# Altium schematic sheet Sheet1.SchDoc
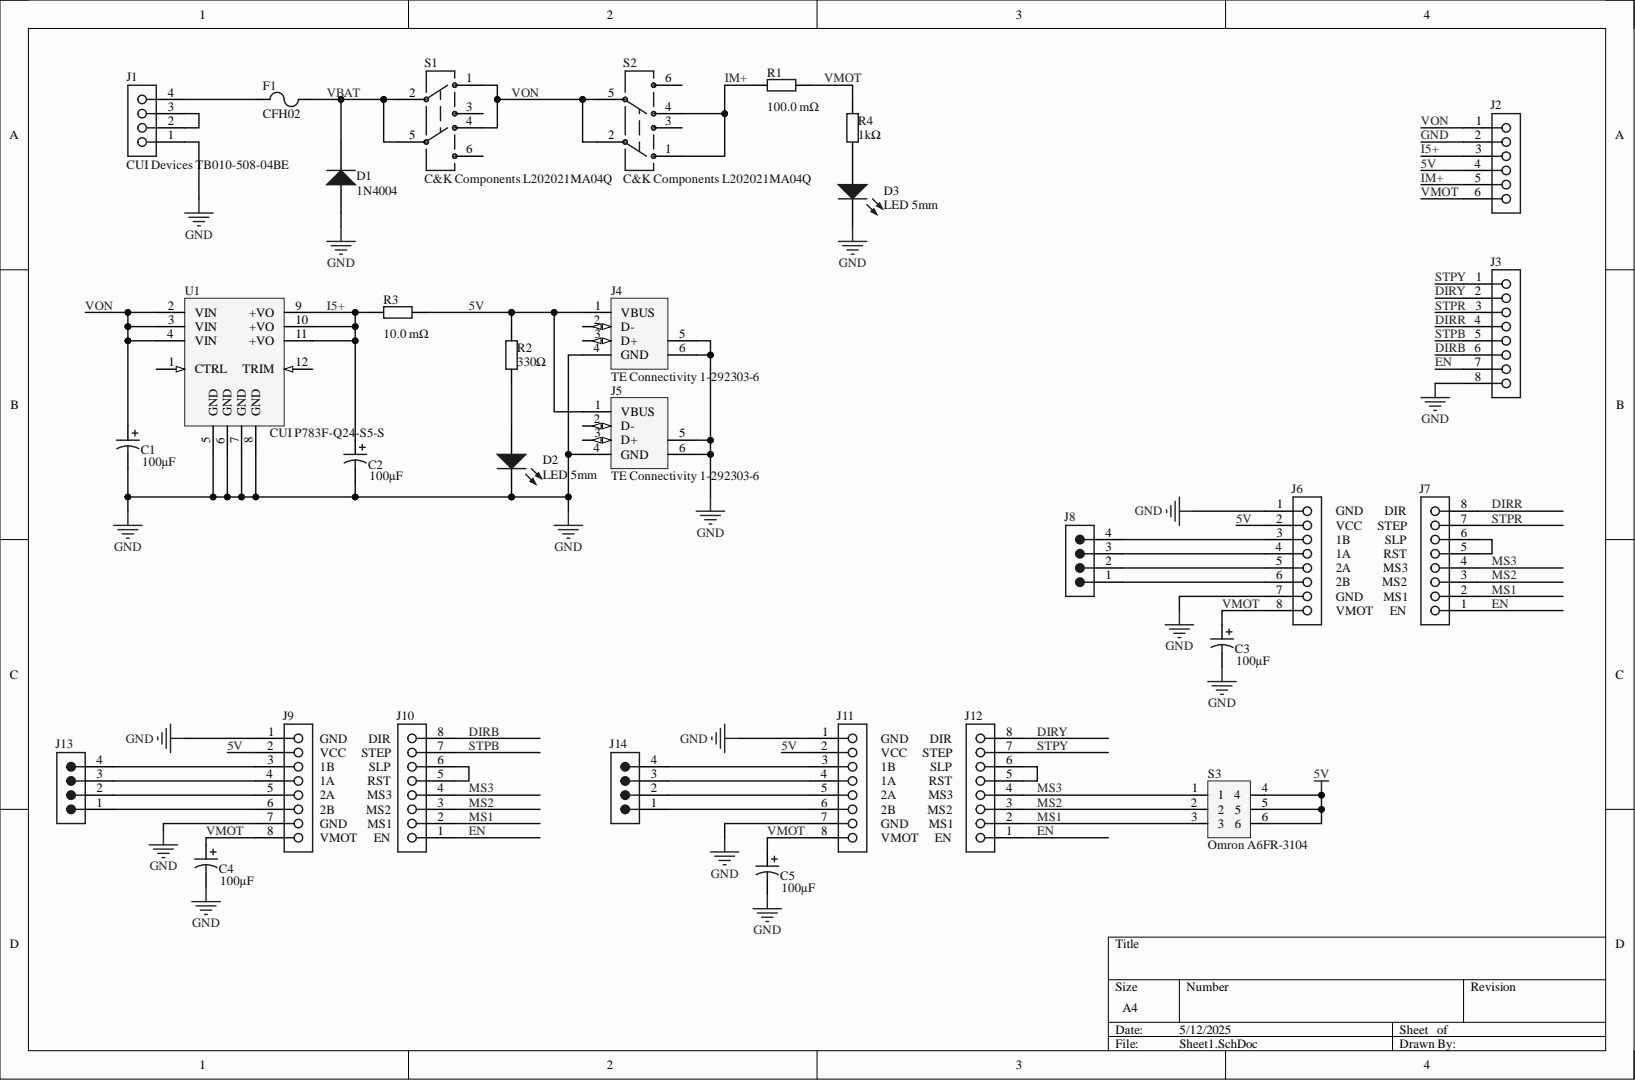
[diagram p0: Sheet1.SchDoc]

## Parts
C1  100µF  [PCB-2jf9nlde2fxplectirwc-1]
C2  100µF  [PCB-2jf9nlde2fxplectirwc-1]
C3  100µF  [PCB-2jf9nlde2fxplectirwc-1]
C4  100µF  [PCB-2jf9nlde2fxplectirwc-1]
C5  100µF  [PCB-2jf9nlde2fxplectirwc-1]
D1  1N4004  [PCB-z2ypi7zr74mzpyjelpsh-1]
D2  LED 5mm  [PCB-pophbrb9lq922oh53f1z-1]
D3  LED 5mm  [PCB-pophbrb9lq922oh53f1z-1]
F1  CFH02  [CFH02]
J1  CUI Devices TB010-508-04BE  Manufacturer Part Number: TB010-508-04BE  [CUI Devices TB010-508-04BE]
J2  SSW-106-02-F-S-RA  [SMTC-SSW-106-02-X-S-RA]  (value not drawn)
J3  SSW-108-01-G-S  [SMTC-SSW-108-01-G-S]  (value not drawn)
J4  TE Connectivity 1-292303-6  Manufacturer Part Number: 1-292303-6  [PCB-cj7j3841b5wradhs55ft-4]
J5  TE Connectivity 1-292303-6  Manufacturer Part Number: 1-292303-6  [PCB-cj7j3841b5wradhs55ft-4]
J6  SSW-108-01-G-S  [SMTC-SSW-108-01-G-S]  (value not drawn)
J7  SSW-108-01-G-S  [SMTC-SSW-108-01-G-S]  (value not drawn)
J8  Samtec TSW-104-08-L-S-RA  [PCB-4501639-1]  (value not drawn)
J9  SSW-108-01-G-S  [SMTC-SSW-108-01-G-S]  (value not drawn)
J10  SSW-108-01-G-S  [SMTC-SSW-108-01-G-S]  (value not drawn)
J11  SSW-108-01-G-S  [SMTC-SSW-108-01-G-S]  (value not drawn)
J12  SSW-108-01-G-S  [SMTC-SSW-108-01-G-S]  (value not drawn)
J13  Samtec TSW-104-08-L-S-RA  [PCB-4501639-1]  (value not drawn)
J14  Samtec TSW-104-08-L-S-RA  [PCB-4501639-1]  (value not drawn)
R1  Resistance: 100.0 mΩ  [OAR1-R010FI]  (hidden comment: Welwyn OAR1 - R010FI)
R2  330Ω  [MF25 1R]
R3  Resistance: 10.0 mΩ  [OAR1-R010FI]  (hidden comment: Welwyn OAR1 - R010FI)
R4  1kΩ  [MF25 1R]
S1  C&K Components L202021MA04Q  [PCB-h1u365uctq0s82hwytnm-1]
S2  C&K Components L202021MA04Q  Manufacturer Part Number: L202021MA04Q  [PCB-h1u365uctq0s82hwytnm-1]
S3  Omron A6FR-3104  Manufacturer Part Number: A6FR-3104  [PCB-ien0rced2w8udwv6jfdf-1]
U1  CUI P783F-Q24-S5-S  Manufacturer Part Number: P783F-Q24-S5-S  [CUI P783F-Q24-S5-S]

## Nets
5V: J2.4 J4.1(VBUS) J5.1(VBUS) J6.2 J9.2 J11.2 R2.2 R3.2 S3.4 S3.5 S3.6
DIRB: J3.6 J10.8
DIRR: J3.4 J7.8
DIRY: J3.2 J12.8
EN: J3.7 J7.1 J10.1 J12.1
GND: C1.2 C2.2 C3.2 C4.2 C5.2 D1.1 D2.2 D3.2 J1.1 J2.2 J3.8 J4.4(GND) J4.5 J4.6 J5.4(GND) J5.5 J5.6 J6.1 J6.7 J9.1 J9.7 J11.1 J11.7 U1.5(GND) U1.6(GND) U1.7(GND) U1.8(GND)
I5+: C2.1 J2.3 R3.1 U1.9(+VO) U1.10(+VO) U1.11(+VO)
IM+: J2.5 R1.1 S2.1 S2.4
MS1: J7.2 J10.2 J12.2 S3.3
MS2: J7.3 J10.3 J12.3 S3.2
MS3: J7.4 J10.4 J12.4 S3.1
STPB: J3.5 J10.7
STPR: J3.3 J7.7
STPY: J3.1 J12.7
VBAT: D1.2 F1.2 S1.2 S1.5
VMOT: C3.1 C4.1 C5.1 J2.6 J6.8 J9.8 J11.8 R1.2 R4.2
VON: C1.1 J2.1 S1.1 S1.4 S2.2 S2.5 U1.2(VIN) U1.3(VIN) U1.4(VIN)
NetD2_1: D2.1 R2.1
NetD3_1: D3.1 R4.1
NetF1_1: F1.1 J1.4
NetJ1_2: J1.2 J1.3
NetJ6_3: J6.3 J8.4
NetJ6_4: J6.4 J8.3
NetJ6_5: J6.5 J8.2
NetJ6_6: J6.6 J8.1
NetJ7_5: J7.5 J7.6
NetJ9_3: J9.3 J13.4
NetJ9_4: J9.4 J13.3
NetJ9_5: J9.5 J13.2
NetJ9_6: J9.6 J13.1
NetJ10_5: J10.5 J10.6
NetJ11_3: J11.3 J14.4
NetJ11_4: J11.4 J14.3
NetJ11_5: J11.5 J14.2
NetJ11_6: J11.6 J14.1
NetJ12_5: J12.5 J12.6

Not connected: J4 2(D-) 3(D+); J5 2(D-) 3(D+); S1 3 6; S2 3 6; U1 1(CTRL) 12(TRIM)

## Library data of the parts
C1-C5 = CMP-1b08d3184bfdc4cf-1: Cap Aluminum Lytic 100uF 16V 20% (6.3 X 11.2mm) Radial 2.5mm 290mA 1000h 105C Ammo Pack; Manufacturer Part Number: EEU-FC1C101H; Component Kind: Standard; Library Name: CommunityVault; Lifecycle Status: Not Listed by Manufacturer; Octopart URL: http://octopart.com/eeu-fc1c101h-panasonic-18511251
D1 = CMP-816519-3: Standard Recovery Rectifier, 2-Pin Axial_Lead, Pb-Free, Tape and Reel; Current Rating: 1.0 A; Lead-Free Status: Lead Free; Voltage Rating (DC): 50.0 V; Case/Package: DO-41; Component Kind: Standard; Halogen Free Status: Halogen Free; Library Name: CommunityVault; Lifecycle Status: Active; Mounting Style: Axial; Number of Pins: 2; Octopart Url: https://octopart.com/1n4001rlg-on+semiconductor-322109; OctopartID: 8cde45d043855319; Packaging: Cut Tape (CT); Polarity: Standard; REACH SVHC Compliance: No SVHC; RoHS: Compliant
D2 D3 = CMP-9d1f5758637d42d8-5: TLHR5205 Red LED, 625 nm 5mm (T-1 3/4), Round Lens Through Hole package; Forward Voltage: 2.00 V; Lead Length: 24.0 mm; Luminous Intensity: 70.0 mcd; Peak Wavelength: 635 nm; Viewing Angle: 14 °; Wavelength: 625 nm; Color: Red; Component Kind: Standard; Library Name: My Personal Space; Manufacturer Part Number: TLHR5205; Mounting Style: Through Hole, Radial; Number of Pins: 2; Octopart URL: http://octopart.com/tlhr5205-vishay-55433988; OctopartID: 9d1f5758637d42d8; Voltage Rating (DC): 2.00 V
F1 = CMP-jsq7mpiehlwut1rbuuav-4: FUSEHOLDER, PCB, 5X20MM; Manufacturer: CamdenBoss; Component Kind: Standard; CustomComponentID: jsq7mpiehlwut1rbuuav; Library Name: CommunityVault; Part No: CFH02
J1 = CMP-84ca4b95a3ef5b0d-1: 2~24 Poles, Screw Type, Horizontal, 5.08 Pitch, 26~12 (AWG), Terminal Block Connector; Component Kind: Standard; Library Name: My Personal Space; Octopart Url: https://octopart.com/tb010-508-04be-cui+devices-106234435; OctopartID: 84ca4b95a3ef5b0d
J2 = CMP-6448856-2: SQ Post Socket, Through-hole, Right Angle, -55 to 125 degC, 2.54mm Pitch, 6-Pin, Female, RoHS; Number of Mating Cycles: 100; Pin Pitch: 0.00254 m; Color: Black; Component Kind: Standard; Contact Material: Phosphor Bronze; Contact Plating: Gold; Library Name: My Personal Space; Manufacturer Part Number: SSW-106-02-F-S-RA; Mounting Style: Through Hole; Number of Rows: 1; Octopart Url: https://octopart.com/ssw-106-02-f-s-ra-samtec-17993425; OctopartID: d8f510ed73420732; RoHS: Yes
J3 J6 J7 J9-J12 = CMP-678528-2: SOCKET, Through-hole, Vertical, -55 to 125 degC, 2.54 mm Pitch, 8-Pin Female, RoHS; Contact Resistance: 0.01 Ω; Lead-Free Status: Lead Free; Number of Contacts: 8; Number of Mating Cycles: 100; Pin Pitch: 0.00254 m; Color: Black; Component Kind: Standard; Contact Material: Phosphor Bronze; Contact Plating: Gold; Gender: Female; Housing Color: Black; Housing Material: Plastic; Library Name: My Personal Space; Manufacturer Part Number: SSW-108-01-G-S; Mounting Style: Through Hole; Number of Positions: 8; Number of Rows: 1; Octopart Url: https://octopart.com/ssw-108-01-g-s-samtec-296063; OctopartID: ee7a27744f6331bd; Packaging: Tube; REACH SVHC Compliance: No SVHC; RoHS: Yes; Size-Height: 0.00851 m; Size-Length: 0.02083 m; Size-Width: 0.00241 m
J4 J5 = CMP-6422acad0472bbe5-10: Conn USB 2.0 Type A RCP 4 POS Solder RA SMD 4 Terminal 1 Port Box/Tray; ELV Compliant: Compliant; Gender: Female; Lead-Free Status: Lead Free; Component Kind: Standard; Contact Plating: Gold Flash, Gold Flash over Nickel, Gold, Gold over Nickel; Flammability Rating: UL 94 V-0; Housing Color: Black; Housing Material: Thermoplastic; Library Name: My Personal Space; Lifecycle Status: Active; Mounting Style: Surface Mount, Horizontal; Octopart URL: https://octopart.com/1-292303-6-te+connectivity-25511222; OctopartID: 6422acad0472bbe5; Packaging: Tray, Box; RoHS: Compliant
J8 J13 J14 = CMP-4501639-3: CONN HEADER 4POS .100 SGL R/A AU; Gender: Male; Lead-Free Status: Lead Free; Number of Contacts: 4; Pin Pitch: 0.00254 m; Component Kind: Standard; Contact Material: Phosphor Bronze; Contact Plating: Gold; Housing Color: Black; Housing Material: Plastic; Library Name: My Personal Space; Manufacturer Part Number: TSW-104-08-L-S-RA; Mounting Style: Through Hole; Number of Positions: 4; Number of Rows: 1; Octopart Url: https://octopart.com/tsw-104-08-l-s-ra-samtec-272899; OctopartID: a7936e9da8f67854; REACH SVHC Compliance: No SVHC; RoHS: Compliant; Size-Height: 0.00302 m; Size-Length: 0.01016 m; Size-Width: 0.00254 m
R1 R3 = CMP-3e3bf763c07ee1fc-1: Resistor, 1% 0R010; Resistance: 0.01ohm; Product Range: OAR Series; Power Rating: 1W; Resistor Element Material:...; Lead Length: 3.18 mm; Power Rating: 1.00 W; Temperature Coefficient: -20.0 ppm/°C to 20.0 ppm/°C; Component Kind: Standard; Library Name: My Personal Space; Manufacturer Part Number: OAR1 - R010FI; Mounting Style: Through Hole; Octopart Url: https://octopart.com/oar1+-+r010fi-welwyn-5430051; OctopartID: 3e3bf763c07ee1fc; Operating Temperature: -40.0 °C to 125 °C; REACH SVHC Compliance: No SVHC; Resistance Tolerance: ±1%; RoHS: Compliant
R2 = CMP-f14c38172e7b2e8b-1: Resistor, 0.25w 1% 330Ω; Manufacturer Part Number: MF25 1K; Lead Length: 28.0 mm; Power Rating: 250 mW; Resistance: 1kΩ; Voltage Rating (DC): 250 V; Component Kind: Standard; Library Name: FavoritesLibrary; Number of Pins: 2; Octopart URL: http://octopart.com/mf25+1r-multicomp-5372497; OctopartID: f14c38172e7b2e8b; REACH SVHC Compliance: No SVHC; Resistance Tolerance: ±1%; RoHS: Compliant
R4 = CMP-f14c38172e7b2e8b-1: Resistor, 0.25w 1% 1kΩ; Manufacturer Part Number: MF25 1K; Lead Length: 28.0 mm; Power Rating: 250 mW; Voltage Rating (DC): 250 V; Component Kind: Standard; Library Name: FavoritesLibrary; Number of Pins: 2; Octopart URL: http://octopart.com/mf25+1r-multicomp-5372497; OctopartID: f14c38172e7b2e8b; REACH SVHC Compliance: No SVHC; Resistance: 1kΩ; Resistance Tolerance: ±1%; RoHS: Compliant
S1 S2 = CMP-3130f81fea99eef7-1: Switch Slide DPDT Extended Side Slide 4A 250VAC 125VDC PC Pins Thru-Hole Bulk; Manufacturer: C&K Switches; Current Rating: 10.0 A; Lead-Free Status: Lead Free; Component Kind: Standard; Contact Material: Silver; Contacts Type: DPDT; Library Name: My Personal Space; Mounting Style: Through Hole; Octopart URL: http://octopart.com/l202021ma04q-c%26k+components-2490063; OctopartID: 3130f81fea99eef7; Orientation: Right Angle; REACH SVHC Compliance: No SVHC; RoHS: Compliant
  S1: Manufacturer Part Number: L202021MA04Q
S3 = CMP-d3541f323bab0c93-1: Switch DIP OFF ON SPST 3 Long Piano 0.025A 24VDC PC Pins 1000Cycles 2.54mm Thru-Hole Tube; Contacts Type: SPST-NO; Component Kind: Standard; Library Name: My Personal Space; Octopart URL: https://octopart.com/a6fr-3104-omron-91016601; OctopartID: d3541f323bab0c93; REACH SVHC Compliance: No SVHC; RoHS: Compliant
U1 = CMP-4e6981e5092e91ae-1: Module DC-DC 24VIN 1-OUT 5V 3A 15W 12-Pin SIP Module Tray; Component Kind: Standard; Library Name: My Personal Space; Octopart Url: https://octopart.com/p783f-q24-s5-s-cui-109470241; OctopartID: 4e6981e5092e91ae
